# Altium schematic sheet Transceivers.SchDoc(Transceivers)
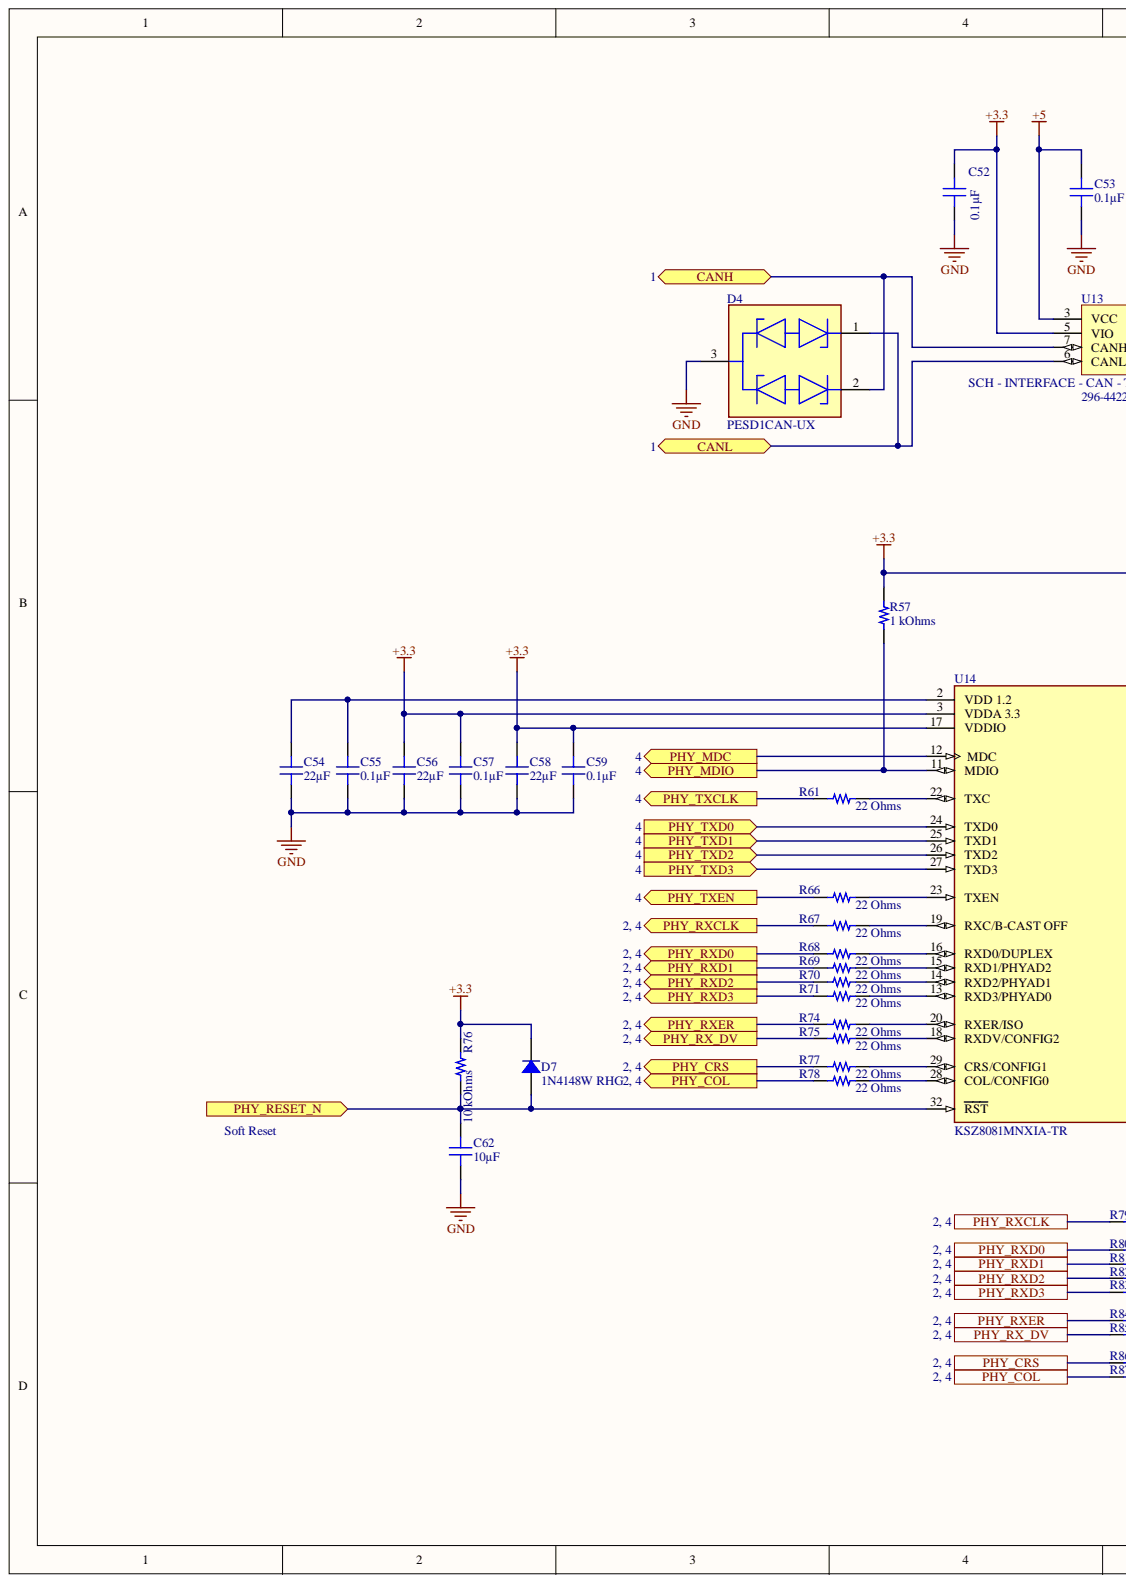
[diagram p0: Transceivers.SchDoc, left]
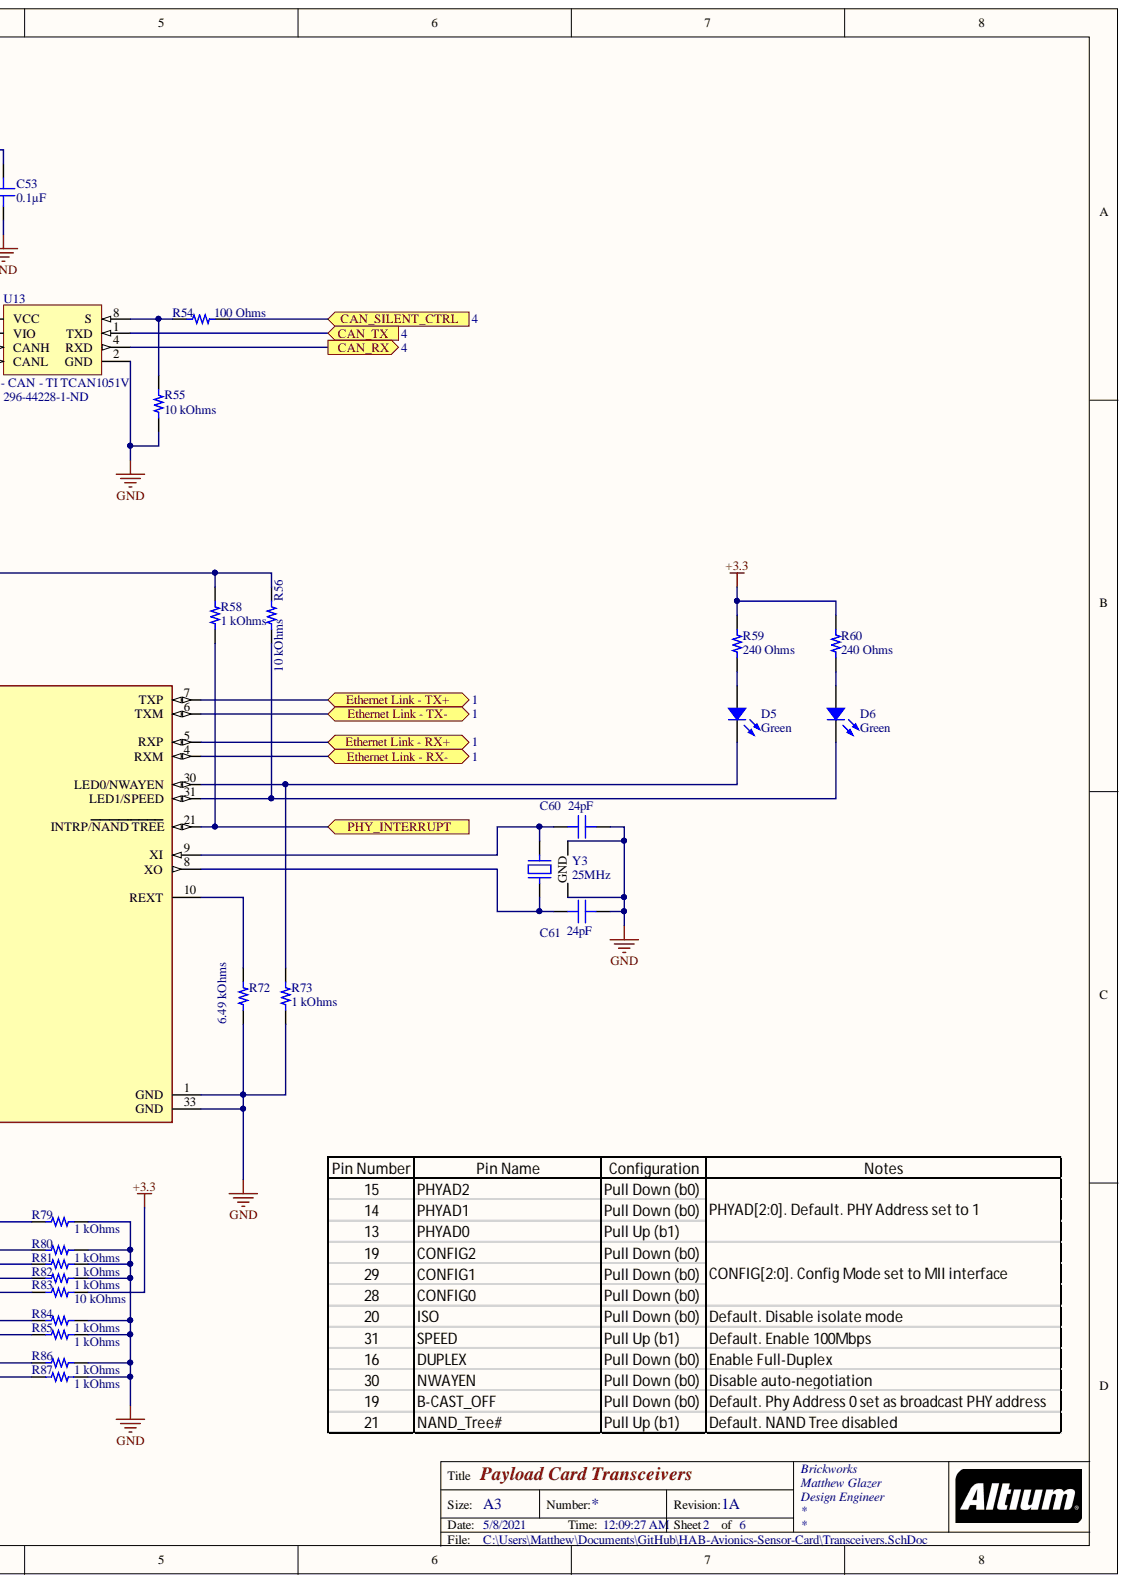
[diagram p1: Transceivers.SchDoc, right]

## Parts
C52  0.1µF  [CAP 0603_1608]
C53  0.1µF  [CAP 0603_1608]
C54  22µF  [CAP 0805_2012]
C55  0.1µF  [CAP 0603_1608]
C56  22µF  [CAP 0805_2012]
C57  0.1µF  [CAP 0603_1608]
C58  22µF  [CAP 0805_2012]
C59  0.1µF  [CAP 0603_1608]
C60  24pF  [CAP 0603_1608]
C61  24pF  [CAP 0603_1608]
C62  10µF  [CAP 0805_2012]
D4  PESD1CAN-UX  [FP-SOT323-MFG]
D5  Green  [LED 0603_1608 GREEN]
D6  Green  [LED 0603_1608 GREEN]
D7  1N4148W RHG  [SOD123F]
R54  100 Ohms  [RES 0603_1608]
R55  10 kOhms  [RES 0603_1608]
R56  10 kOhms  [RES 0603_1608]
R57  1 kOhms  [RES 0603_1608]
R58  1 kOhms  [RES 0603_1608]
R59  240 Ohms  [RES 0603_1608]
R60  240 Ohms  [RES 0603_1608]
R61  22 Ohms  [RES 0603_1608]
R66  22 Ohms  [RES 0603_1608]
R67  22 Ohms  [RES 0603_1608]
R68  22 Ohms  [RES 0603_1608]
R69  22 Ohms  [RES 0603_1608]
R70  22 Ohms  [RES 0603_1608]
R71  22 Ohms  [RES 0603_1608]
R72  6.49 kOhms  [RES 0603_1608]
R73  1 kOhms  [RES 0603_1608]
R74  22 Ohms  [RES 0603_1608]
R75  22 Ohms  [RES 0603_1608]
R76  10 kOhms  [RES 0603_1608]
R77  22 Ohms  [RES 0603_1608]
R78  22 Ohms  [RES 0603_1608]
R79  1 kOhms  [RES 0603_1608]
R80  1 kOhms  [RES 0603_1608]
R81  1 kOhms  [RES 0603_1608]
R82  1 kOhms  [RES 0603_1608]
R83  10 kOhms  [RES 0603_1608]
R84  1 kOhms  [RES 0603_1608]
R85  1 kOhms  [RES 0603_1608]
R86  1 kOhms  [RES 0603_1608]
R87  1 kOhms  [RES 0603_1608]
U13  SCH - INTERFACE - CAN - TI TCAN1051V  Supplier Part Number 1: 296-44228-1-ND  [PCB - LEADS - SOIC - TI SOIC-8]
U14  KSZ8081MNXIA-TR  [FP-QFN55-32LD-PL-5-MFG]
Y3  25MHz  [EPSON TSX-3225]

## Ports
CAN_RX, CAN_SILENT_CTRL, CAN_TX, CANH, CANL, Ethernet Link - RX+, Ethernet Link - RX-, Ethernet Link - TX+, Ethernet Link - TX-, PHY_COL, PHY_CRS, PHY_INTERRUPT, PHY_MDC, PHY_MDIO, PHY_RESET_N, PHY_RX_DV, PHY_RXCLK, PHY_RXD0, PHY_RXD1, PHY_RXD2, PHY_RXD3, PHY_RXER, PHY_TXCLK, PHY_TXD0, PHY_TXD1, PHY_TXD2, PHY_TXD3, PHY_TXEN

## Nets
+3.3: C52.2 C56.2 C57.2 C58.2 C59.2 D7.2 R56.2 R57.2 R58.2 R59.2 R60.2 R76.2 R83.1 U13.5(VIO) U14.3(VDDA_3.3) U14.17(VDDIO)
+5: C53.2 U13.3(VCC)
GND: C52.1 C53.1 C54.1 C55.1 C56.1 C57.1 C58.1 C59.1 C60.2 C61.2 C62.1 D4.3 R55.1 R72.1 R73.1 R79.1 R80.1 R81.1 R82.1 R84.1 R85.1 R86.1 R87.1 U13.2(GND) U14.1(GND) U14.33(GND) Y3.2(GND) Y3.4
CAN_RX: U13.4(RXD) ; port CAN_RX
CAN_SILENT_CTRL: R54.2 ; port CAN_SILENT_CTRL
CAN_TX: U13.1(TXD) ; port CAN_TX
CANH: D4.2 U13.7(CANH) ; port CANH
CANL: D4.1 U13.6(CANL) ; port CANL
PHY_COL: R78.1 R87.2 ; port PHY_COL
PHY_CRS: R77.1 R86.2 ; port PHY_CRS
PHY_INTERRUPT: R58.1 U14.21(INTRP/NAND_TREE) ; port PHY_INTERRUPT
PHY_MDC: U14.12(MDC) ; port PHY_MDC
PHY_MDIO: R57.1 U14.11(MDIO) ; port PHY_MDIO
PHY_RESET_N: C62.2 D7.1 R76.1 U14.32(RST) ; port PHY_RESET_N
PHY_RX_DV: R75.1 R85.2 ; port PHY_RX_DV
PHY_RXCLK: R67.1 R79.2 ; port PHY_RXCLK
PHY_RXD0: R68.1 R80.2 ; port PHY_RXD0
PHY_RXD1: R69.1 R81.2 ; port PHY_RXD1
PHY_RXD2: R70.1 R82.2 ; port PHY_RXD2
PHY_RXD3: R71.1 R83.2 ; port PHY_RXD3
PHY_RXER: R74.1 R84.2 ; port PHY_RXER
PHY_TXCLK: R61.1 ; port PHY_TXCLK
PHY_TXD0: U14.24(TXD0) ; port PHY_TXD0
PHY_TXD1: U14.25(TXD1) ; port PHY_TXD1
PHY_TXD2: U14.26(TXD2) ; port PHY_TXD2
PHY_TXD3: U14.27(TXD3) ; port PHY_TXD3
PHY_TXEN: R66.1 ; port PHY_TXEN
NetC54_2: C54.2 C55.2 U14.2(VDD_1.2)
NetC60_1: C60.1 U14.9(XI) Y3.3
NetC61_1: C61.1 U14.8(XO) Y3.1
NetD5_1: D5.1 R59.1
NetD5_2: D5.2 R73.2 U14.30(LED0/NWAYEN)
NetD6_1: D6.1 R60.1
NetD6_2: D6.2 R56.1 U14.31(LED1/SPEED)
NetR54_1: R54.1 R55.2 U13.8(S)
NetR61_2: R61.2 U14.22(TXC)
NetR66_2: R66.2 U14.23(TXEN)
NetR67_2: R67.2 U14.19(RXC/B-CAST_OFF)
NetR68_2: R68.2 U14.16(RXD0/DUPLEX)
NetR69_2: R69.2 U14.15(RXD1/PHYAD2)
NetR70_2: R70.2 U14.14(RXD2/PHYAD1)
NetR71_2: R71.2 U14.13(RXD3/PHYAD0)
NetR72_2: R72.2 U14.10(REXT)
NetR74_2: R74.2 U14.20(RXER/ISO)
NetR75_2: R75.2 U14.18(RXDV/CONFIG2)
NetR77_2: R77.2 U14.29(CRS/CONFIG1)
NetR78_2: R78.2 U14.28(COL/CONFIG0)
NetU14_4: U14.4(RXM)
NetU14_5: U14.5(RXP)
NetU14_6: U14.6(TXM)
NetU14_7: U14.7(TXP)

## Library data of the parts
C52 C53 C55 C57 C59 = CL10B104KA8WPNC: CAP CER 0.1UF 25V X7R 0603; Manufacturer: Samsung Electro-Mechanics; Tolerance: ±10%; Database Table Name: Capacitors - Ceramic - 0603; Voltage - Rated: 25V; Value: 0.1µF; Thickness (Max): 0.035" (0.90mm); Temperature Coefficient: X7R; Supplier Part Number 1: 1276-6717-1-ND; Supplier 1: DigiKey; Size / Dimension: 0.063" L x 0.031" W (1.60mm x 0.80mm); Series: CL; Ratings: AEC-Q200; Price: 0.02; Part Status: Active; Part Number: CL10B104KA8WPNC; PartId: 5631; Packaging: TapeAndReel; Package / Case: 0603 (1608 Metric); Operating Temperature: -55°C ~ 125°C; Mounting Type: Surface Mount, MLCC; Minimum Order: 1; Lifecycle Status: Active; Library Name: Celestial Altium Library - altium_library.DbLib; LastUpdated: 9/2/2020 1:03:30 AM; Features: Open Mode; Device Package: 0603 (1608 Metric); ComponentLink2URL: http://digikey.com/product-detail/en/samsung-electro-mechanics/CL10B104KA8WPNC/1276-6717-1-ND/5961576; ComponentLink2Description: DigiKey Link; ComponentLink1URL: http://www.samsungsem.com/kr/support/product-search/mlcc/CL10B104KA8WPNC.jsp; ComponentLink1Description: Datasheet; Component Kind: Standard; Capacitance: 0.1µF; Applications: Automotive
C54 C56 C58 = CL21A226KPCLRNC: CAP CER 22UF 10V X5R 0805; Manufacturer: Samsung Electro-Mechanics; Tolerance: ±10%; Database Table Name: Capacitors - Ceramic - 0805; Voltage - Rated: 10V; Value: 22µF; Thickness (Max): 0.037" (0.95mm); Temperature Coefficient: X5R; Supplier Part Number 1: 1276-6786-1-ND; Supplier 1: DigiKey; Size / Dimension: 0.079" L x 0.049" W (2.00mm x 1.25mm); Series: CL; Price: 0.08; Part Status: Active; Part Number: CL21A226KPCLRNC; PartId: 4833; Packaging: TapeAndReel; Package / Case: 0805 (2012 Metric); Operating Temperature: -55°C ~ 85°C; Mounting Type: Surface Mount, MLCC; Minimum Order: 1; Lifecycle Status: Active; Library Name: Celestial Altium Library - altium_library.DbLib; LastUpdated: 9/2/2020 1:04:38 AM; Features: Low Profile; Device Package: 0805 (2012 Metric); ComponentLink2URL: http://digikey.com/product-detail/en/samsung-electro-mechanics/CL21A226KPCLRNC/1276-6786-1-ND/5961645; ComponentLink2Description: DigiKey Link; ComponentLink1URL: http://www.samsungsem.com/kr/support/product-search/mlcc/CL21A226KPCLRNC.jsp; ComponentLink1Description: Datasheet; Component Kind: Standard; Capacitance: 22µF; Applications: General Purpose
C60 C61 = CL10C240JB8NNNC: CAP CER 24PF 50V C0G/NP0 0603; Manufacturer: Samsung Electro-Mechanics; Tolerance: ±5%; Temperature Coefficient: C0G, NP0; Database Table Name: Capacitors - Ceramic - 0603; Voltage - Rated: 50V; Value: 24pF; Thickness (Max): 0.035" (0.90mm); Supplier Part Number 1: 1276-1761-1-ND; Supplier 1: DigiKey; Size / Dimension: 0.063" L x 0.031" W (1.60mm x 0.80mm); Series: CL; Price: 0.01; Part Status: Active; Part Number: CL10C240JB8NNNC; PartId: 10003; Packaging: TapeAndReel; Package / Case: 0603 (1608 Metric); Operating Temperature: -55°C ~ 125°C; Mounting Type: Surface Mount, MLCC; Minimum Order: 1; Lifecycle Status: Active; Library Name: Celestial Altium Library - altium_library.DbLib; LastUpdated: 9/2/2020 1:03:22 AM; Device Package: 0603 (1608 Metric); ComponentLink2URL: http://digikey.com/product-detail/en/samsung-electro-mechanics/CL10C240JB8NNNC/1276-1761-1-ND/3889847; ComponentLink2Description: DigiKey Link; ComponentLink1URL: http://www.samsungsem.com/kr/support/product-search/mlcc/CL10C240JB8NNNC.jsp; ComponentLink1Description: Datasheet; Component Kind: Standard; Capacitance: 24pF; Applications: General Purpose
C62 = CC0805KKX5R7BB106: CAP CER 10UF 16V X5R 0805; Manufacturer: Yageo; Tolerance: ±10%; Database Table Name: Capacitors - Ceramic - 0805; Voltage - Rated: 16V; Value: 10µF; Thickness (Max): 0.057" (1.45mm); Temperature Coefficient: X5R; Supplier Part Number 1: 311-1865-1-ND; Supplier 1: DigiKey; Size / Dimension: 0.079" L x 0.049" W (2.00mm x 1.25mm); Series: CC; Price: 0.09; Part Status: Active; Part Number: CC0805KKX5R7BB106; PartId: 8867; Packaging: TapeAndReel; Package / Case: 0805 (2012 Metric); Operating Temperature: -55°C ~ 85°C; Mounting Type: Surface Mount, MLCC; Minimum Order: 1; Lifecycle Status: Active; Library Name: Celestial Altium Library - altium_library.DbLib; LastUpdated: 9/2/2020 1:04:30 AM; Device Package: 0805 (2012 Metric); ComponentLink2URL: http://digikey.com/product-detail/en/yageo/CC0805KKX5R7BB106/311-1865-1-ND/5195767; ComponentLink2Description: DigiKey Link; ComponentLink1URL: https://www.yageo.com/upload/media/product/productsearch/datasheet/mlcc/UPY-GPHC_X5R_4V-to-50V_25.pdf; ComponentLink1Description: Datasheet; Component Kind: Standard; Capacitance: 10µF; Applications: General Purpose
D4 = CMP-14436-000006-1: TVS DIODE 24V 50V SC70-3; Library Name: Altium Content Vault; Component Kind: Standard
D5 D6 = LTST-C193KGKT-5A: LED GREEN CLEAR CHIP SMD; Manufacturer: Lite-On Inc.; Wavelength - Peak: 574nm; Wavelength - Dominant: 572nm; Voltage - Forward (Vf) (Typ): 2V; Viewing Angle: 130°; Millicandela Rating: 16.25mcd; Lens Style: Rectangle with Flat Top; Lens Size: 1.15mm x 0.80mm; Lens Color: Colorless; Database Table Name: LED - Indication; Current - Test: 5mA; Configuration: Standard; Value: Green; Supplier Part Number 1: 160-1828-1-ND; Supplier Device Package: Chip LED; Supplier 1: DigiKey; Size / Dimension: 1.60mm L x 0.80mm W; Series: -; Price: 0.06; Part Status: Active; Part Number: LTST-C193KGKT-5A; PartId: 694; Packaging: TapeAndReel; Package / Case: 0603 (1608 Metric); Mounting Type: Surface Mount; Minimum Order: 1; Lifecycle Status: Active; Library Name: Celestial Altium Library - altium_library.DbLib; Lens Transparency: Clear; LastUpdated: 9/2/2020 1:20:41 AM; Height (Max): 0.45mm; Device Package: 0603 (1608 Metric); ComponentLink2URL: http://digikey.com/product-detail/en/lite-on-inc/LTST-C193KGKT-5A/160-1828-1-ND/2356247; ComponentLink2Description: DigiKey Link; ComponentLink1URL: http://optoelectronics.liteon.com/upload/download/DS22-2007-0094/LTST-C193KGKT-5A.PDF; ComponentLink1Description: Datasheet; Component Kind: Standard; Color: Green
D7 = 1N4148W RHG: DIODE GEN PURP 100V 150MA SOD123; Manufacturer: Taiwan Semiconductor Corporation; Voltage - Forward (Vf) (Max) @ If: 1V @ 100mA; Speed: Fast Recovery =< 500ns, > 200mA (Io); Reverse Recovery Time (trr): 4ns; Diode Type: Standard; Database Table Name: Diodes - Rectifiers - Single; Current - Reverse Leakage @ Vr: 5µA @ 75V; Current - Average Rectified (Io): 150mA (DC); Voltage - DC Reverse (Vr) (Max): 100V; Supplier Part Number 1: 1N4148WRHGCT-ND; Supplier Device Package: SOD-123F; Supplier 1: DigiKey; Series: -; Price: 0.03; Part Status: Active; Part Number: 1N4148W RHG; PartId: 1073; Packaging: TapeAndReel; Package / Case: SOD-123F; Operating Temperature - Junction: -65°C ~ 150°C; Mounting Type: Surface Mount; Minimum Order: 1; Lifecycle Status: Active; Library Name: Celestial Altium Library - altium_library.DbLib; LastUpdated: 9/2/2020 1:19:10 AM; ComponentLink2URL: http://digikey.com/product-detail/en/taiwan-semiconductor-corporation/1N4148W-RHG/1N4148WRHGCT-ND/7357066; ComponentLink2Description: DigiKey Link; ComponentLink1URL: https://www.taiwansemi.com/products/datasheet/1N4148W%20SERIES_K1804.pdf; ComponentLink1Description: Datasheet; Component Kind: Standard
R54 = RMCF0603FG100R: RES 100 OHM 1% 1/10W 0603; Manufacturer: Stackpole Electronics Inc; Temperature Coefficient: ±100ppm/°C; Power (Watts): 0.1W, 1/10W; Database Table Name: Resistors - Surface Mount - 0603; Value: 100 Ohms; Tolerance: ±1%; Supplier Part Number 1: RMCF0603FG100RCT-ND; Supplier Device Package: 0603; Supplier 1: DigiKey; Size / Dimension: 0.061" L x 0.031" W (1.55mm x 0.80mm); Series: RMCF; Resistance: 100 Ohms; Ratings: AEC-Q200; Price: 0.10; Part Status: Active; Part Number: RMCF0603FG100R; PartId: 24036; Packaging: CutTape; Package / Case: 0603 (1608 Metric); Operating Temperature: -55°C ~ 155°C; Number of Terminations: 2; Minimum Order: 1; Lifecycle Status: Active; Library Name: Celestial Altium Library - altium_library.DbLib; LastUpdated: 9/2/2020 1:09:39 AM; Height - Seated (Max): 0.022" (0.55mm); Features: Automotive AEC-Q200; Device Package: 0603 (1608 Metric); Composition: Thick Film; ComponentLink2URL: http://digikey.com/product-detail/en/stackpole-electronics-inc/RMCF0603FG100R/RMCF0603FG100RCT-ND/4425033; ComponentLink2Description: DigiKey Link; ComponentLink1URL: https://www.seielect.com/catalog/sei-rmcf_rmcp.pdf; ComponentLink1Description: Datasheet; Component Kind: Standard
R55 R56 R76 R83 = RMCF0603JT10K0: RES 10K OHM 5% 1/10W 0603; Manufacturer: Stackpole Electronics Inc; Temperature Coefficient: ±200ppm/°C; Power (Watts): 0.1W, 1/10W; Database Table Name: Resistors - Surface Mount - 0603; Value: 10 kOhms; Tolerance: ±5%; Supplier Part Number 1: RMCF0603JT10K0CT-ND; Supplier Device Package: 0603; Supplier 1: DigiKey; Size / Dimension: 0.061" L x 0.031" W (1.55mm x 0.80mm); Series: RMCF; Resistance: 10 kOhms; Ratings: AEC-Q200; Price: 0.10; Part Status: Active; Part Number: RMCF0603JT10K0; PartId: 25659; Packaging: CutTape; Package / Case: 0603 (1608 Metric); Operating Temperature: -55°C ~ 155°C; Number of Terminations: 2; Minimum Order: 1; Lifecycle Status: Active; Library Name: Celestial Altium Library - altium_library.DbLib; LastUpdated: 8/30/2020 8:48:45 PM; Height - Seated (Max): 0.022" (0.55mm); Features: Automotive AEC-Q200; Device Package: 0603 (1608 Metric); Composition: Thick Film; ComponentLink2URL: http://digikey.com/product-detail/en/stackpole-electronics-inc/RMCF0603JT10K0/RMCF0603JT10K0CT-ND/1943191; ComponentLink2Description: DigiKey Link; ComponentLink1URL: https://www.seielect.com/catalog/sei-packaging.pdf; ComponentLink1Description: Datasheet; Component Kind: Standard
R57 R58 R73 R79-R82 R84-R87 = RNCP0603FTD1K00: RES 1K OHM 1% 1/8W 0603; Manufacturer: Stackpole Electronics Inc; Temperature Coefficient: ±100ppm/°C; Power (Watts): 0.125W, 1/8W; Database Table Name: Resistors - Surface Mount - 0603; Value: 1 kOhms; Tolerance: ±1%; Supplier Part Number 1: RNCP0603FTD1K00CT-ND; Supplier Device Package: 0603; Supplier 1: DigiKey; Size / Dimension: 0.059" L x 0.031" W (1.50mm x 0.80mm); Series: RNCP; Resistance: 1 kOhms; Price: 0.01; Part Status: Active; Part Number: RNCP0603FTD1K00; PartId: 5694; Packaging: TapeAndReel; Package / Case: 0603 (1608 Metric); Operating Temperature: -55°C ~ 155°C; Number of Terminations: 2; Minimum Order: 1; Lifecycle Status: Active; Library Name: Celestial Altium Library - altium_library.DbLib; LastUpdated: 9/2/2020 1:10:23 AM; Height - Seated (Max): 0.020" (0.50mm); Features: Anti-Sulfur, Moisture Resistant; Device Package: 0603 (1608 Metric); Composition: Thin Film; ComponentLink2URL: http://digikey.com/product-detail/en/stackpole-electronics-inc/RNCP0603FTD1K00/RNCP0603FTD1K00CT-ND/2240445; ComponentLink2Description: DigiKey Link; ComponentLink1URL: https://www.seielect.com/catalog/sei-rncp.pdf; ComponentLink1Description: Datasheet; Component Kind: Standard
R59 R60 = RMCF0603JT240R: RES 240 OHM 5% 1/10W 0603; Manufacturer: Stackpole Electronics Inc; Temperature Coefficient: ±200ppm/°C; Power (Watts): 0.1W, 1/10W; Database Table Name: Resistors - Surface Mount - 0603; Value: 240 Ohms; Tolerance: ±5%; Supplier Part Number 1: RMCF0603JT240RCT-ND; Supplier Device Package: 0603; Supplier 1: DigiKey; Size / Dimension: 0.061" L x 0.031" W (1.55mm x 0.80mm); Series: RMCF; Resistance: 240 Ohms; Ratings: AEC-Q200; Price: 0.10; Part Status: Active; Part Number: RMCF0603JT240R; PartId: 5995; Packaging: CutTape; Package / Case: 0603 (1608 Metric); Operating Temperature: -55°C ~ 155°C; Number of Terminations: 2; Minimum Order: 1; Lifecycle Status: Active; Library Name: Celestial Altium Library - altium_library.DbLib; LastUpdated: 9/2/2020 1:10:22 AM; Height - Seated (Max): 0.022" (0.55mm); Features: Automotive AEC-Q200; Device Package: 0603 (1608 Metric); Composition: Thick Film; ComponentLink2URL: http://digikey.com/product-detail/en/stackpole-electronics-inc/RMCF0603JT240R/RMCF0603JT240RCT-ND/2418189; ComponentLink2Description: DigiKey Link; ComponentLink1URL: https://www.seielect.com/catalog/sei-rmcf_rmcp.pdf; ComponentLink1Description: Datasheet; Component Kind: Standard
R61 R66-R71 R74 R75 R77 R78 = RMCF0603FT22R0: RES 22 OHM 1% 1/10W 0603; Manufacturer: Stackpole Electronics Inc; Temperature Coefficient: ±100ppm/°C; Power (Watts): 0.1W, 1/10W; Database Table Name: Resistors - Surface Mount - 0603; Value: 22 Ohms; Tolerance: ±1%; Supplier Part Number 1: RMCF0603FT22R0CT-ND; Supplier Device Package: 0603; Supplier 1: DigiKey; Size / Dimension: 0.061" L x 0.031" W (1.55mm x 0.80mm); Series: RMCF; Resistance: 22 Ohms; Ratings: AEC-Q200; Price: 0.10; Part Status: Active; Part Number: RMCF0603FT22R0; PartId: 10611; Packaging: CutTape; Package / Case: 0603 (1608 Metric); Operating Temperature: -55°C ~ 155°C; Number of Terminations: 2; Minimum Order: 1; Lifecycle Status: Active; Library Name: Celestial Altium Library - altium_library.DbLib; LastUpdated: 9/2/2020 1:10:13 AM; Height - Seated (Max): 0.022" (0.55mm); Features: Automotive AEC-Q200; Device Package: 0603 (1608 Metric); Composition: Thick Film; ComponentLink2URL: http://digikey.com/product-detail/en/stackpole-electronics-inc/RMCF0603FT22R0/RMCF0603FT22R0CT-ND/1942946; ComponentLink2Description: DigiKey Link; ComponentLink1URL: https://www.seielect.com/catalog/sei-packaging.pdf; ComponentLink1Description: Datasheet; Component Kind: Standard
R72 = RNCP0603FTD6K49: RES 6.49K OHM 1% 1/8W 0603; Manufacturer: Stackpole Electronics Inc; Temperature Coefficient: ±100ppm/°C; Power (Watts): 0.125W, 1/8W; Database Table Name: Resistors - Surface Mount - 0603; Value: 6.49 kOhms; Tolerance: ±1%; Supplier Part Number 1: RNCP0603FTD6K49CT-ND; Supplier Device Package: 0603; Supplier 1: DigiKey; Size / Dimension: 0.059" L x 0.031" W (1.50mm x 0.80mm); Series: RNCP; Resistance: 6.49 kOhms; Price: 0.01; Part Status: Active; Part Number: RNCP0603FTD6K49; PartId: 22308; Packaging: TapeAndReel; Package / Case: 0603 (1608 Metric); Operating Temperature: -55°C ~ 155°C; Number of Terminations: 2; Minimum Order: 1; Lifecycle Status: Active; Library Name: Celestial Altium Library - altium_library.DbLib; LastUpdated: 9/2/2020 1:09:47 AM; Height - Seated (Max): 0.020" (0.50mm); Features: Anti-Sulfur, Moisture Resistant; Device Package: 0603 (1608 Metric); Composition: Thin Film; ComponentLink2URL: http://digikey.com/product-detail/en/stackpole-electronics-inc/RNCP0603FTD6K49/RNCP0603FTD6K49CT-ND/2240472; ComponentLink2Description: DigiKey Link; ComponentLink1URL: https://www.seielect.com/catalog/sei-rncp.pdf; ComponentLink1Description: Datasheet; Component Kind: Standard
U14 = CMP-06281-000005-1: IC TRANSCEIVER FULL 1/1 32QFN; Manufacturer: Microchip; Standoff Height: 0mm; Simplex Duplex: Full Duplex; Number Of Channels: 1; Min Supply Voltage: 1.71V; Max Supply Voltage: 3.465V; Max Junction Temp: +125°C; Interface: Ethernet,Other; Data Rate: 100Mbits/s; Temperature Range Low: -40°C; Temperature Range High: +85°C; Temperature: -40°C to +85°C; RoHS: TRUE; Package: QFN55-32LD-PL-5; Octopart: https://octopart.com/ksz8081mnxia-tr-microchip-66799958; Mouser Description: Ethernet ICs 10/100 PHY,  0.11u, I-Temp; Manufacturer Part Number: KSZ8081MNXIA-TR; Library Name: Altium Content Vault; Lead Free: Yes; Height: 1mm; Digikey Description: IC TRANSCEIVER FULL 1/1 32QFN; Device Class L3: Ethernet Interface ICs; Device Class L2: Interface ICs; Device Class L1: Integrated Circuits (ICs); ComponentLink2URL: http://ww1.microchip.com/downloads/en/DeviceDoc/00002202C.pdf; ComponentLink2Description: Datasheet; Component Kind: Standard; Category: IC; Automotive: No; Ambient Temperature Range Low: -40°C; Ambient Temperature Range High: +85°C
Y3 = TSX-3225 25.0000MF20P-C0: CRYSTAL 25.0000MHZ 18PF SMD; Manufacturer: EPSON; Operating Mode: Fundamental; Frequency Tolerance: ±10ppm; Frequency Stability: ±20ppm; ESR (Equivalent Series Resistance): 40 Ohms; Database Table Name: Oscillators - Crystals; Value: 25MHz; Type: MHz Crystal; Supplier Part Number 1: SER4212CT-ND; Supplier 1: DigiKey; Size / Dimension: 0.126" L x 0.098" W (3.20mm x 2.50mm); Series: TSX-3225; Price: 0.18; Part Status: Active; Part Number: TSX-3225 25.0000MF20P-C0; PartId: 447; Packaging: TapeAndReel; Package / Case: 4-SMD, No Lead; Operating Temperature: -20°C ~ 85°C; Mounting Type: Surface Mount; Minimum Order: 1; Load Capacitance: 18pF; Lifecycle Status: Active; Library Name: Celestial Altium Library - altium_library.DbLib; LastUpdated: 9/2/2020 1:21:54 AM; Height - Seated (Max): 0.024" (0.60mm); Frequency: 25MHz; ComponentLink2URL: http://digikey.com/product-detail/en/epson/TSX-3225-25.0000MF20P-C0/SER4212CT-ND/7430967; ComponentLink2Description: DigiKey Link; ComponentLink1URL: https://support.epson.biz/td/api/doc_check.php?dl=brief_TSX-3225&lang=en; ComponentLink1Description: Datasheet; Component Kind: Standard
U13 = SCH - INTERFACE - CAN - TI TCAN1051V: Supplier 1: Digi-Key; Component Kind: Standard; Library Name: HAB_ICs.SchLib
